# Altium schematic sheet USB.SchDoc(USB)
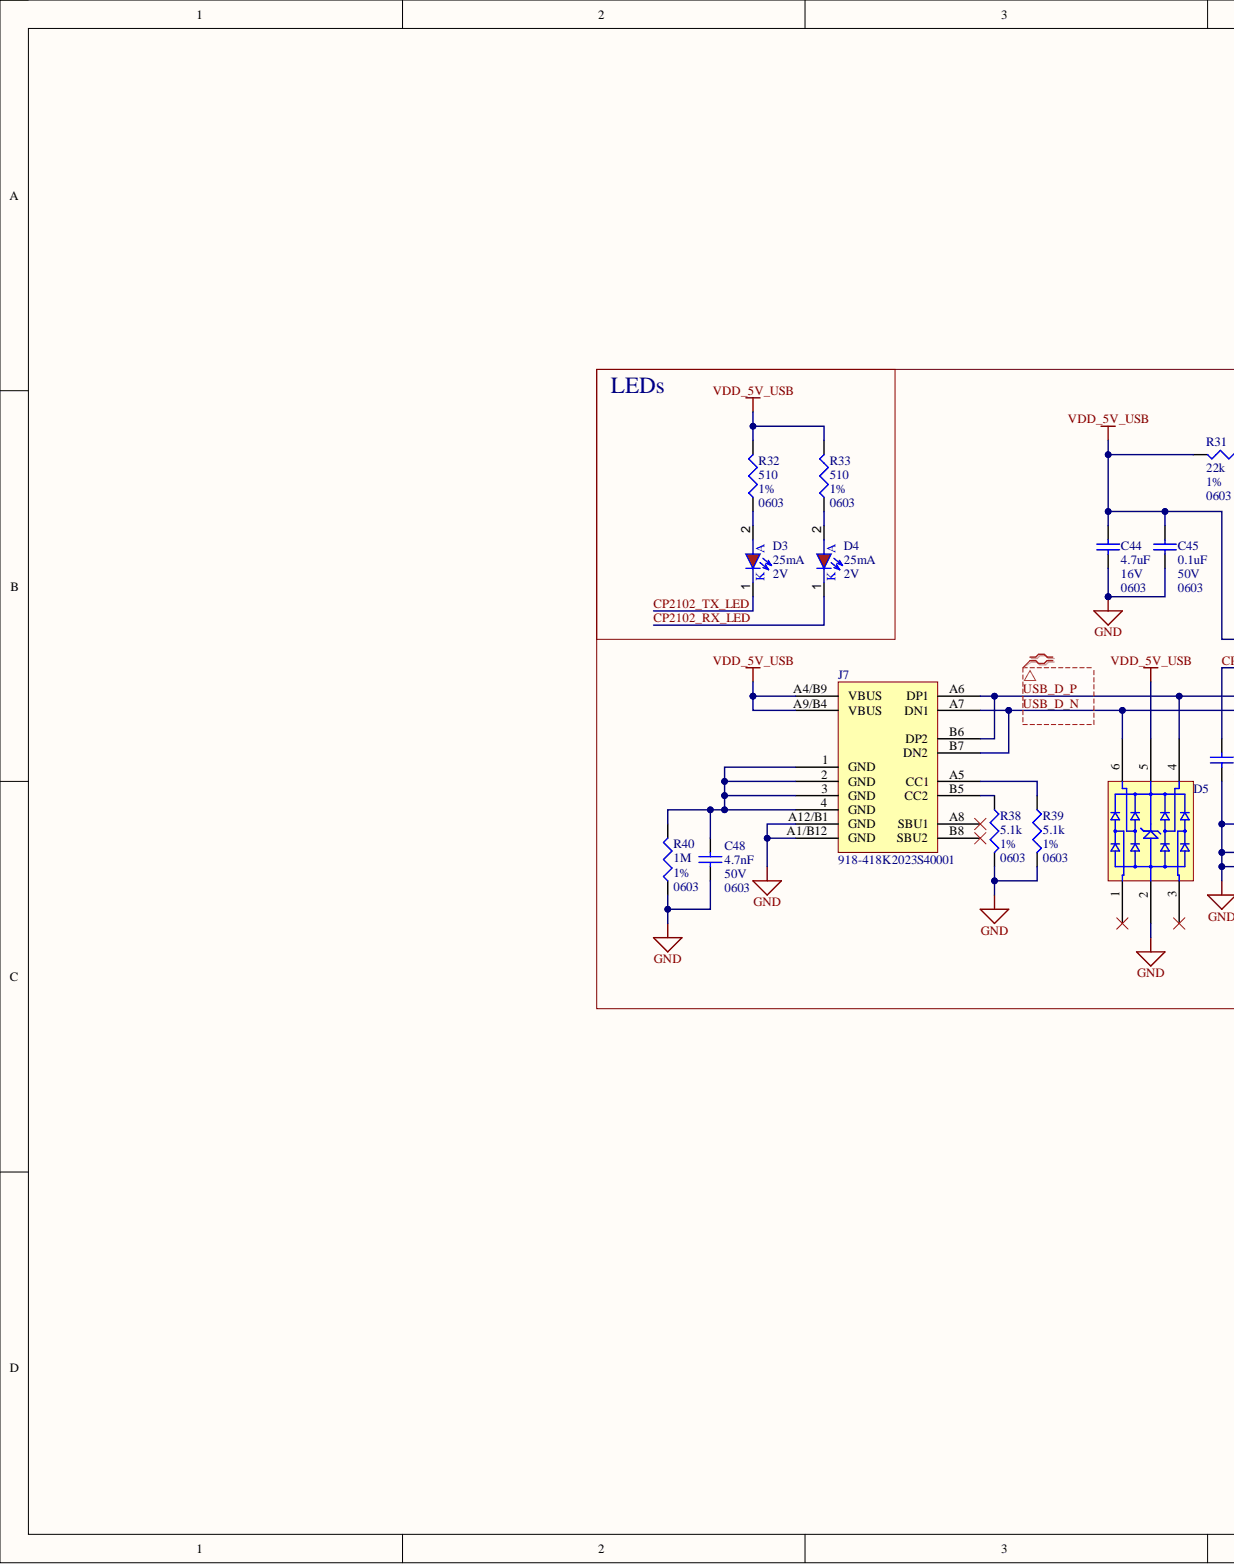
[diagram p0: USB.SchDoc, left]
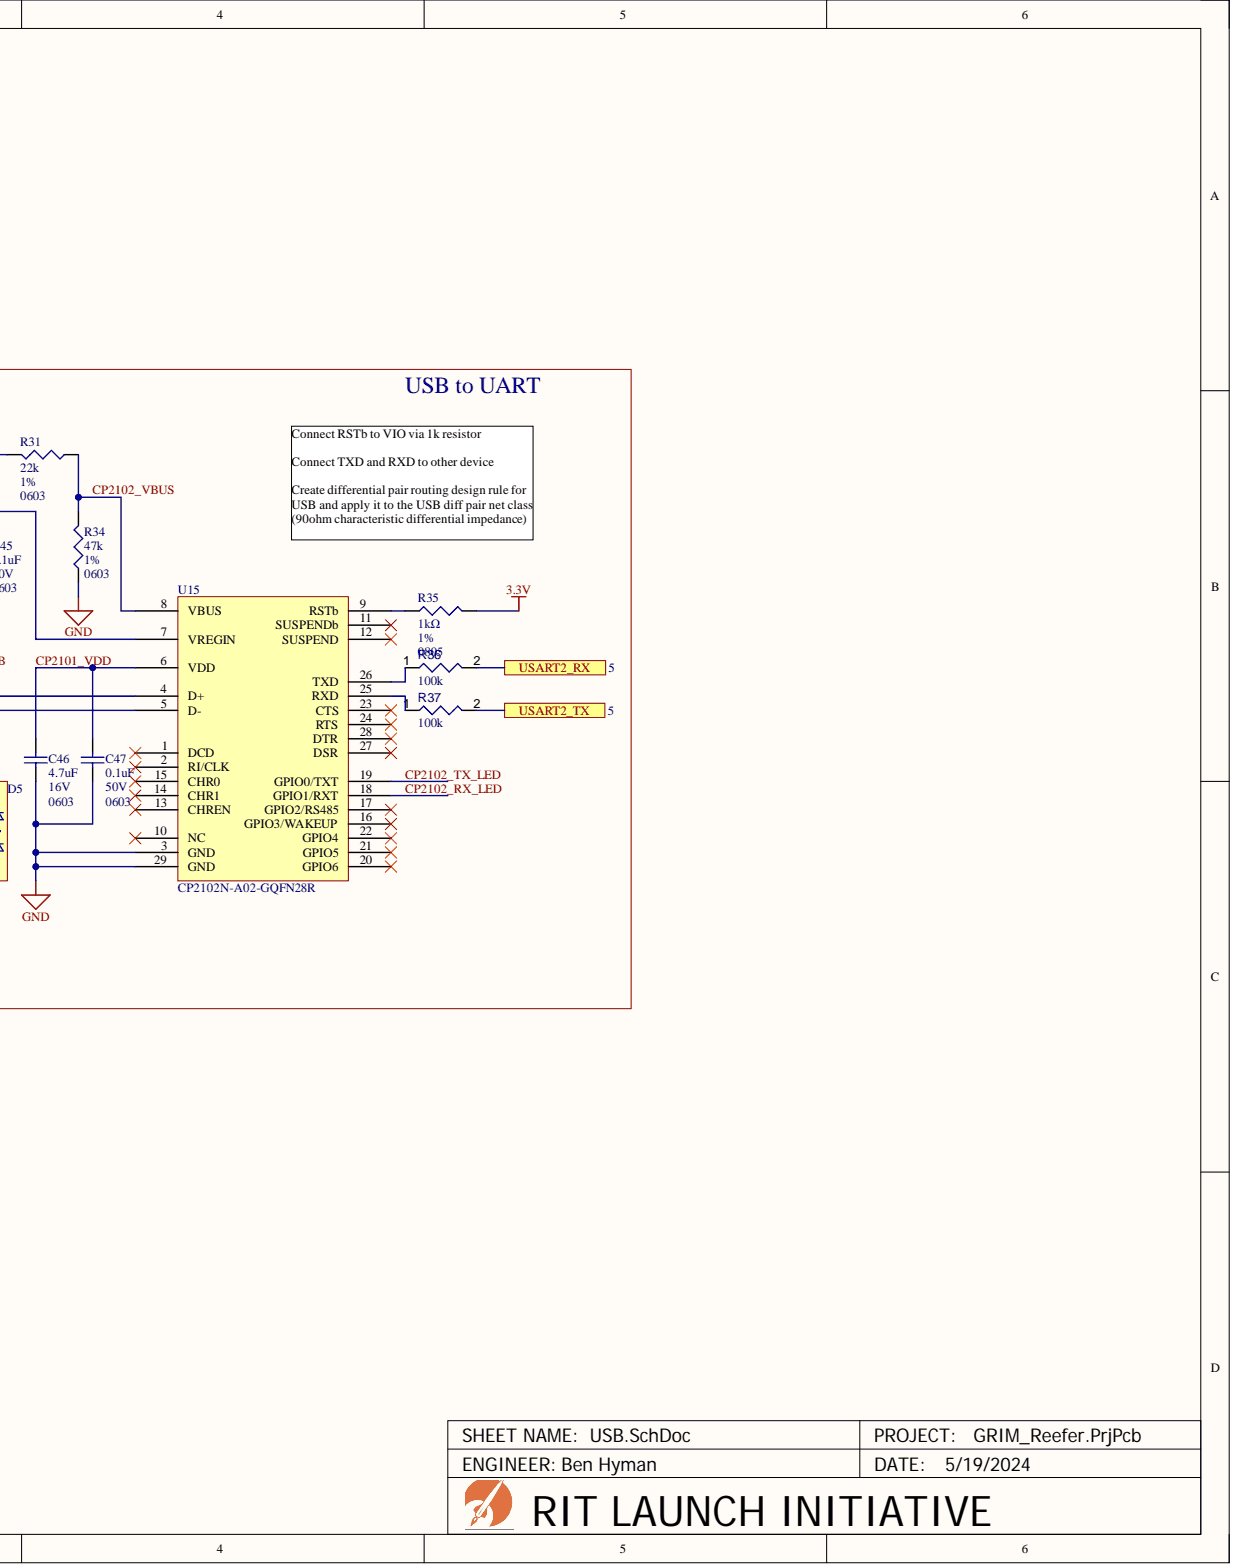
[diagram p1: USB.SchDoc, right]

## Parts
C44  Capacitance: 4.7uF  Case Code (Imperial): 0603  Voltage: 16V  (hidden comment: CL10A475KO8NNNC)
C45  Capacitance: 0.1uF  Case Code (Imperial): 0603  Voltage: 50V  (hidden comment: CC0603KRX7R9BB104)
C46  Capacitance: 4.7uF  Case Code (Imperial): 0603  Voltage: 16V  (hidden comment: CL10A475KO8NNNC)
C47  Capacitance: 0.1uF  Voltage: 50V  (hidden comment: CC0603KRX7R9BB104)
C48  Capacitance: 4.7nF  Case/Package: 0603  Voltage: 50V  (hidden comment: 0603B472K500NT)
D3  Forward Voltage: 2V  Max Current: 25mA  (hidden comment: NCD0805R1)
D4  Forward Voltage: 2V  Max Current: 25mA  (hidden comment: NCD0805R1)
D5  SRV05-4-P-T7  (value not drawn)
J7  918-418K2023S40001
R31  Case/Package: 0603  Resistance: 22k  Tolerance: 1%  (hidden comment: 0603WAF2202T5E)
R32  Case/Package: 0603  Tolerance: 1%  (hidden comment: 0603WAF5100T5E)
R33  Case/Package: 0603  Tolerance: 1%  (hidden comment: 0603WAF5100T5E)
R34  Case/Package: 0603  Resistance: 47k  Tolerance: 1%  (hidden comment: 0603WAF4702T5E)
R35  Resistance: 1kΩ  (hidden comment: 0805W8F1001T5E)
R36  value 100k  Tolerance: 1%  (hidden comment: 1206W4F200JT5E)
R37  value 100k  (hidden comment: 1206W4F200JT5E)
R38  Case/Package: 0603  Resistance: 5.1k  Tolerance: 1%  (hidden comment: 0603WAF5101T5E)
R39  Case/Package: 0603  Resistance: 5.1k  Tolerance: 1%  (hidden comment: 0603WAF5101T5E)
R40  Case/Package: 0603  Resistance: 1M  Tolerance: 1%  (hidden comment: 0603WAF1004T5E)
U15  CP2102N-A02-GQFN28R

## Ports
USART2_RX, USART2_TX

## Nets
3.3V: R35.2
GND: C44.1 C45.1 C46.1 C47.1 C48.1 D5.2 J7.A1/B12(GND) J7.A12/B1(GND) R34.1 R38.2 R39.2 R40.1 U15.3(GND) U15.29(GND)
VDD_5V_USB: C44.2 C45.2 D5.5 J7.A4/B9(VBUS) J7.A9/B4(VBUS) R31.2 R32.2 R33.2 U15.7(VREGIN)
CP2101_VDD: C46.2 C47.2 U15.6(VDD)
CP2102_RX_LED: D4.1 U15.18(GPIO1/RXT)
CP2102_TX_LED: D3.1 U15.19(GPIO0/TXT)
CP2102_VBUS: R31.1 R34.2 U15.8(VBUS)
USART2_RX: R36.2 ; port USART2_RX
USART2_TX: R37.2 ; port USART2_TX
USB_D_N: D5.6 J7.A7(DN1) J7.B7(DN2) U15.5(D-)
USB_D_P: D5.4 J7.A6(DP1) J7.B6(DP2) U15.4(D+)
NetC48_2: C48.2 J7.1(GND) J7.2(GND) J7.3(GND) J7.4(GND) R40.2
NetD3_2: D3.2 R32.1
NetD4_2: D4.2 R33.1
NetJ7_A5: J7.A5(CC1) R39.1
NetJ7_B5: J7.B5(CC2) R38.1
NetR35_1: R35.1 U15.9(RSTb)
NetR36_1: R36.1 U15.26(TXD)
NetR37_1: R37.1 U15.25(RXD)

Not connected: D5 1 3; J7 A8(SBU1) B8(SBU2); U15 1(DCD) 2(RI/CLK) 10(NC) 11(SUSPENDb) 12(SUSPEND) 13(CHREN) 14(CHR1) 15(CHR0) 16(GPIO3/WAKEUP) 17(GPIO2/RS485) 20(GPIO6) 21(GPIO5) 22(GPIO4) 23(CTS) 24(RTS) 27(DSR) 28(DTR)

## Library data of the parts
C44 C46 = CMP-001-0241-2: CAP CER 4.7UF 16V X5R 0603; Material: Ceramic; Tolerance: 10%; Case Code (Metric): 1608; Case/Package: 0603; Component Kind: Standard; Construction: Flat; Contact Plating: Tin; Depth: 0.8mm; Dielectric: X5R; Height: 0.8mm; JLCPCB Part #: C19666; Length: 1.6mm; Max Operating Temperature: 85°C; Min Operating Temperature: -55°C; Mount: Surface Mount; Package Quantity: 1; Packaging: Tape and Reel; Radiation Hardening: No; RoHS Compliant: Yes; Series: CL; Thickness: 0.035inch; Voltage Rating: 16V; Width: 0.031inch; Library Name: RIT Launch Initiative
C45 C47 = CMP-001-0242-3: CAP CER 0.1UF 50V X7R 0603; Dielectric Material: Ceramic; Tolerance: 10%; Case Code (Metric): 1608; Case/Package: 0603; Component Kind: Standard; Depth: 0.8mm; Dielectric: X7R; Halogen Free: Halogen Free; Height: 0.8mm; JLCPCB Part #: C14663; Lead Free: Lead Free; Length: 0.063inch; Max Operating Temperature: 125°C; Min Operating Temperature: -55°C; Mount: Surface Mount; Number of Pins: 2; Package Quantity: 1; Packaging: Tape and Reel; Radiation Hardening: No; REACH SVHC: No SVHC; RoHS Compliant: Yes; Series: CC; Termination: SMD/SMT; Thickness: 0.035inch; Voltage Rating: 50V; Width: 0.031inch; Library Name: RIT Launch Initiative
  C47: Case Code (Imperial): 0603
C48 = CMP-001-0253-2: CAP CER 4.7nF 50V X7R 0603; Component Kind: Standard; JLCPCB Part #: C53987; Library Name: RIT Launch Initiative
D3 D4 = CMP-001-0246-3: LED RED CLEAR 0805 SMD; Component Kind: Standard; JLCPCB Part #: C84256; Library Name: RIT Launch Initiative
D5 = CMP-001-0239-5: SRV05 Series 4 Ch 3.5 pF 15 Vclamp Steering Diode/TVS Array Combo - SOT-23-6; Capacitance: 3.5pF; Clamping Voltage: 15V; Direction: Unidirectional; Leakage Current: 5uA; Number of Channels: 4; Number of Elements: 1; Peak Pulse Current: 5A; Peak Pulse Power: 500W; Reverse Breakdown Voltage: 6V; Reverse Standoff Voltage: 5V; Test Current: 1mA; Case/Package: SOT-23; Clamping Voltage-Max: 15V; Component Kind: Standard; Depth: 1.75mm; JLCPCB Part #: C85364; Lead Free: Lead Free; Length: 3.05mm; Max Operating Temperature: 150°C; Min Operating Temperature: -55°C; Mount: Surface Mount; Number of Pins: 6; Packaging: Tape and Reel; Polarity: Unidirectional; Radiation Hardening: No; RoHS Compliant: Yes; Working Voltage: 5V; Library Name: RIT Launch Initiative
J7 = CMP-001-0238-5: 3A 1 16 Female Type-C SMD USB Connectors ROHS; Gender: Receptacle; Mating Cycles: 10000; Number of Ports: 1; Shielding: Shielded; Component Kind: Standard; Connector Type: USB; Contact Plating: Gold; Flammability Rating: UL94 V-0; Insulation Color: Black; JLCPCB Part #: C709357; Max Operating Temperature: 85°C; Min Operating Temperature: -40°C; Mount: Surface Mount,Through Hole,Horizontal; Series: USB4105; Termination: Solder; Library Name: RIT Launch Initiative
R31 = CMP-001-0240-2: RES 22K OHM 1% 1/10W 0603; Component Kind: Standard; JLCPCB Part #: C31850; Library Name: RIT Launch Initiative
R32 R33 = CMP-001-0248-2: RES 510 OHM 1% 1/10W 0603; Resistance: 510; Component Kind: Standard; JLCPCB Part #: C23193; RoHS Compliant: Yes; Library Name: RIT Launch Initiative
R34 = CMP-001-0243-2: RES 47K OHM 1% 1/10W 0603; Component Kind: Standard; JLCPCB Part #: C25819; Library Name: RIT Launch Initiative
R35 = CMP-009-00195-1: RES SMD 1K OHM 1% 125mW 0805; Voltage: 150V; Case/Package: 0805; Component Kind: Standard; JLCPCB Part #: C17513; Tolerance: 1%; Library Name: RIT Launch Initiative
R36 R37 = CMP-009-00190-3: 250mW Thick Film Resistors 200V ±100ppm/℃ ±1% 20Ω 1206 Chip Resistor - Surface Mount ROHS; Case/Package: 1206; Component Kind: Standard; JLCPCB Part #: C17955; Max Operating Temperature: 155°C; Min Operating Temperature: -55°C; Mounting Technology: SM; Pins: 2; Power: 250mW; RoHS Compliant: True; Voltage Rating: 200V; Library Name: RIT Launch Initiative
  R37: Tolerance: 1%
R38 R39 = CMP-001-0244-2: RES 5.1K OHM 1% 1/10W 0603; Component Kind: Standard; JLCPCB Part #: C23186; RoHS Compliant: Yes; Library Name: RIT Launch Initiative
R40 = CMP-001-0252-2: RES 1M OHM 1% 1/10W 0603; Component Kind: Standard; JLCPCB Part #: C22935; Library Name: RIT Launch Initiative
U15 = CMP-001-0237-4: Full Speed USB to UART Bridge USB 2.0 3.3V T/R Automotive 28-Pin QFN EP; Component Kind: Standard; JLCPCB Part #: C964632; Library Name: RIT Launch Initiative
